# Altium schematic sheet Power.SchDoc(Power)
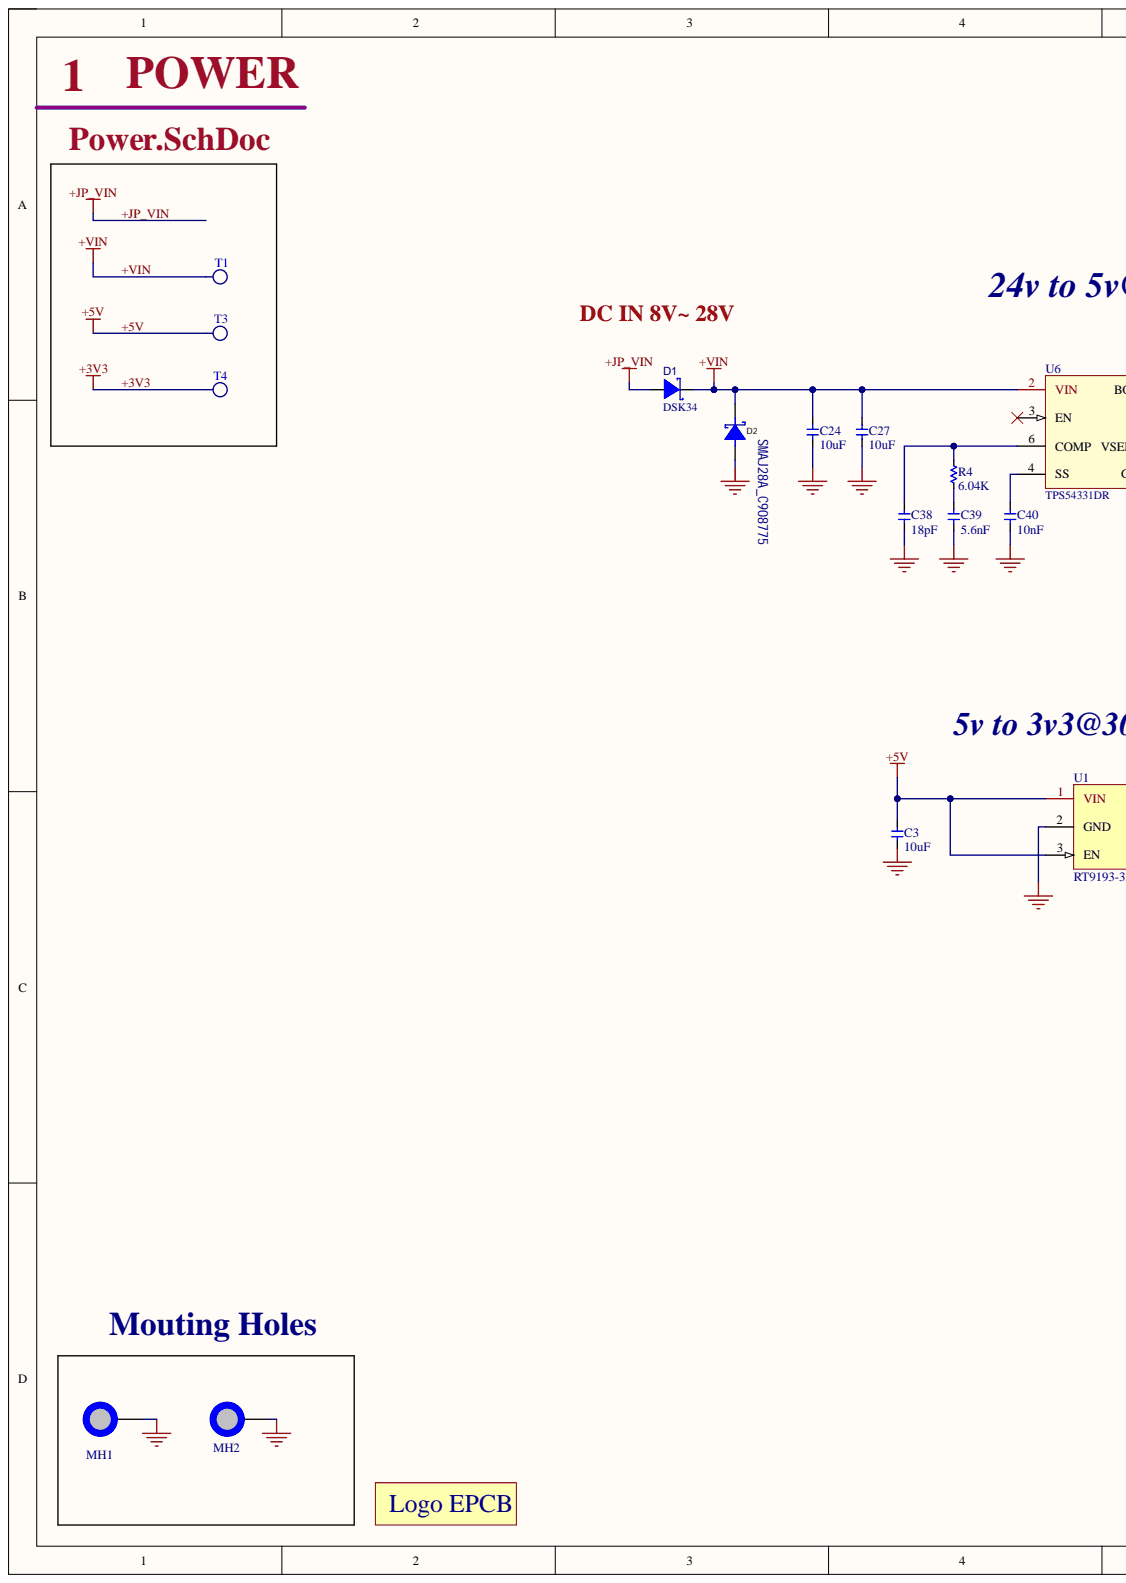
[diagram p0: Power.SchDoc, left]
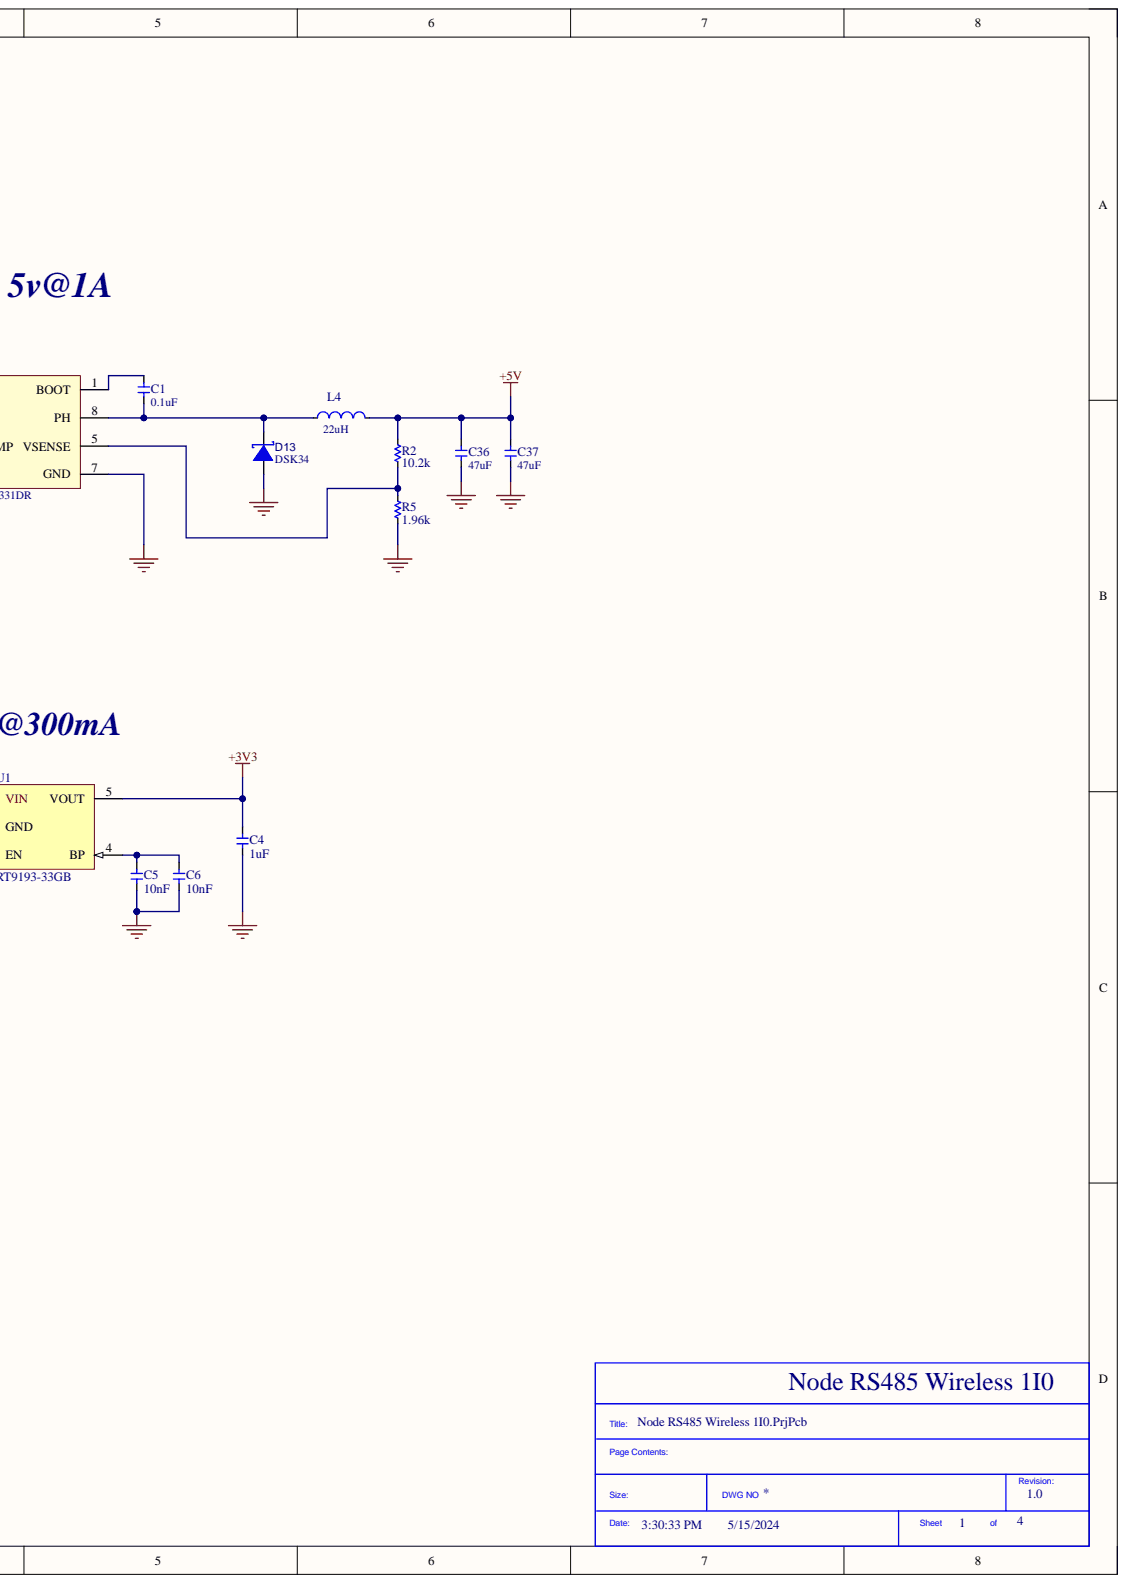
[diagram p1: Power.SchDoc, right]

## Parts
C1  0.1uF  [C_0603]
C3  10uF  [C_0805]
C4  1uF  [C_0603]
C5  10nF  [C_0402]
C6  10nF  [C_0402]
C24  10uF  [C_0805]
C27  10uF  [C_0805]
C36  47uF  [C_0805]
C37  47uF  [C_0805]
C38  18pF  [C_0402]
C39  5.6nF  [C_0402]
C40  10nF  [C_0402]
D1  DSK34  [SOD-123_L2.8-W1.8-LS3.7-RD]
D2  SMAJ28A_C908775  [DO-214AC_L4.3-W2.7-LS5.0-RD]
D13  DSK34  [SOD-123_L2.8-W1.8-LS3.7-RD]
L4  22uH  [IND-SMD_L7.1-W6.6]
LO2  LOGO EPCB  [LOGO EPCB TopLayer]  (value not drawn)  (no BOM)
MH1  MH_6.0MMC_3.5MM  [MH_6.0MMD_3.5MM - Via]  (value not drawn)  (no BOM)
MH2  MH_6.0MMC_3.5MM  [MH_6.0MMD_3.5MM - Via]  (value not drawn)  (no BOM)
R2  10.2k  [R_0402]
R4  6.04K  [R_0402]
R5  1.96k  [R_0402]
T1  TEST-POINT'4545'  [TP-D45MIL]  (value not drawn)  (no BOM)
T3  TEST-POINT'4545'  [TP-D45MIL]  (value not drawn)  (no BOM)
T4  TEST-POINT'4545'  [TP-D45MIL]  (value not drawn)  (no BOM)
U1  RT9193-33GB  [SOT-23-5_L3.0-W1.7-P0.95-LS2.8-BR]
U6  TPS54331DR  [SOIC-8_3.9x4.9x1.27P]

## Nets
GND: C3.1 C4.1 C5.1 C6.1 C24.1 C27.1 C36.1 C37.1 C38.1 C39.1 C40.1 D2.2 D13.2 MH1.1 MH2.1 R5.2 U1.2(GND) U6.7(GND)
+3V3: C4.2 T4.T U1.5(VOUT)
+5V: C3.2 C36.2 C37.2 L4.2 R2.1 T3.T U1.1(VIN) U1.3(EN)
+JP_VIN: D1.2
+VIN: C24.2 C27.2 D1.1 D2.1 T1.T U6.2(VIN)
NetC1_1: C1.1 D13.1 L4.1 U6.8(PH)
NetC1_2: C1.2 U6.1(BOOT)
NetC5_2: C5.2 C6.2 U1.4(BP)
NetC38_2: C38.2 R4.1 U6.6(COMP)
NetC39_2: C39.2 R4.2
NetC40_2: C40.2 U6.4(SS)
NetR2_2: R2.2 R5.1 U6.5(VSENSE)

Not connected: U6 3(EN)

## Library data of the parts
C1 = CC0603KRX7R9BB104: 100NF 50V 0603 ROHS; Manufacturer: YAGEO; Assembly type: SMT Assembly; Component Kind: Standard; ComponentLink1Description: Digikey Link; ComponentLink1URL: https://www.digikey.com/en/products/detail/yageo/CC0603KRX7R9BB104/2103082?s=N4IgTCBcDaIMwEYEFoFwCzuWZA5AIiALoC%2BQA; ComponentLink2Description: LCSC Link; ComponentLink2URL: https://jlcpcb.com/parts/componentSearch?searchTxt=10k%200603; LCSC Part Number: C14663; Library Name: Node RS485 Wireless 1I0.SCHLIB; Manufacturer Part Number: CC0603KRX7R9BB104; Package: 0603
C3 = CL21A106KAYNNNE: 10UF 25V 0805 ROHS; Manufacturer: Samsung Electro-Mechanics; Assembly Type: SMT Assembly; Component Kind: Standard; ComponentLink1Description: LCSC Link; ComponentLink1URL: https://lcsc.com/product-detail/Multilayer-Ceramic-Capacitors-MLCC-SMD-SMT_SAMSUNG_CL21A106KAYNNNE_10uF-106-10-25V_C15850.html/?href=jlc-SMT; LCSC Part Number: C15850; Library Name: USB to Multi RS485 1.0.SCHLIB; Manufacturer Part Number: CL21A106KAYNNNE; Package: 0805
C4 = CL10A105KB8NNNC: 1UF 50V 0603 ROHS; Manufacturer: Samsung Electro-Mechanics; Assembly Type: SMT Assembly; Component Kind: Standard; ComponentLink1Description: Digikey Link; ComponentLink1URL: https://www.digikey.com/en/products/detail/samsung-electro-mechanics/CL10A105KB8NNNC/3887518?s=N4IgTCBcDaIMIBkCMAGAgqgrAaQEIA4A5YuEAXQF8g; ComponentLink2Description: LCSC Link; ComponentLink2URL: https://jlcpcb.com/parts/componentSearch?searchTxt=10k%200603; LCSC Part Number: C15849; Library Name: Dev Board STM OLED 2.0.SCHLIB; Manufacturer Part Number: CL10A105KB8NNNC; Package: 0603
C5 C6 C40 = 0402B103K500NT: 10nF 50V X7R ±10% 0402 Multilayer Ceramic Capacitors MLCC - SMD/SMT ROH; Manufacturer: FH(Guangdong Fenghua Advanced Tech); Manufacturer Part Number: 0402CG120J500NT; Assembly Type: SMT Assembly; Component Kind: Standard; ComponentLink1Description: Digikey Link; ComponentLink2Description: LCSC Link; ComponentLink2URL: https://jlcpcb.com/parts/componentSearch?searchTxt=10k%200603; LCSC Part Number: C1547; Package: 0402
  C5 C6: Library Name: Dev Board STM OLED 2.0.SchLib
  C40: Library Name: Node RS485 Wireless 1I0.SCHLIB
C24 C27 = GRM21BR61H106KE43L: 10uF ±10% 50V X5R 0805; Manufacturer: Murata Electronics; Assembly Type: SMT Assembly; Component Kind: Standard; ComponentLink1Description: LCSC Link; ComponentLink1URL: https://lcsc.com/product-detail/Multilayer-Ceramic-Capacitors-MLCC-SMD-SMT_SAMSUNG_CL21A106KAYNNNE_10uF-106-10-25V_C15850.html/?href=jlc-SMT; LCSC Part Number: C440198; Library Name: Node RS485 Wireless 1I0.SCHLIB; Manufacturer Part Number: GRM21BR61H106KE43L; Package: 0805
C36 C37 = GRM21BR61A476ME15L: 47uF ±20% 10V X5R 0805 Multilayer Ceramic Capacitors MLCC - SMD/SMT RoHS; Manufacturer: Murata Electronics; Assembly Type: SMT Assembly; Component Kind: Standard; ComponentLink1Description: LCSC Link; ComponentLink1URL: https://lcsc.com/product-detail/Multilayer-Ceramic-Capacitors-MLCC-SMD-SMT_SAMSUNG_CL21A106KAYNNNE_10uF-106-10-25V_C15850.html/?href=jlc-SMT; LCSC Part Number: C124129; Library Name: Node RS485 Wireless 1I0.SCHLIB; Manufacturer Part Number: GRM21BR61A476ME15L; Package: 0805
C38 = 0402CG180J500NT: 50V 18pF C0G ±5% 0402 Multilayer Ceramic Capacitors MLCC - SMD/SMT ROHS; Manufacturer: FH (Guangdong Fenghua Advanced Tech); Assembly Type: SMT Assembly; Component Kind: Standard; ComponentLink1Description: Digikey Link; ComponentLink1URL: https://www.digikey.com/en/products/detail/yageo/CC0603KRX7R9BB104/2103082?s=N4IgTCBcDaIMwEYEFoFwCzuWZA5AIiALoC%2BQA; ComponentLink2Description: LCSC Link; ComponentLink2URL: https://jlcpcb.com/parts/componentSearch?searchTxt=10k%200603; LCSC Part Number: C1549; Library Name: Node RS485 Wireless 1I0.SCHLIB; Manufacturer Part Number: 0402CG180J500NT; Package: 0402
C39 = 0402B562K500NT: 5.6nF 50V X7R ±10% 0402  Multilayer Ceramic Capacitors MLCC - SMD/SMT ROHS; Manufacturer: FH(Guangdong Fenghua Advanced Tech); Assembly Type: SMT Assembly; Component Kind: Standard; ComponentLink1Description: Digikey Link; ComponentLink2Description: LCSC Link; ComponentLink2URL: https://jlcpcb.com/parts/componentSearch?searchTxt=10k%200603; LCSC Part Number: C1540; Library Name: Node RS485 Wireless 1I0.SCHLIB; Manufacturer Part Number: 0402B562K500NT; Package: 0402
D1 D13 = DSK34: DSK34 40V 550mV@3A 3A SOD-123 Schottky Barrier Diodes (SBD) ROHS Copy; Manufacturer: MDD（Microdiode Electronics); Assembly Type: SMT Assembly; Component Kind: Standard; LCSC Part Number: C41029; Library Name: Node RS485 Wireless 1I0.SCHLIB; Manufacturer Part Number: DSK34; Package: SOD-123
D2 = SMAJ28A_C908775: SMAJ28A 8.8A 45.4V 31.1V 28V SMA(DO-214AC)  Electrostatic and Surge Protection (TVS/ESD) ROHS; Manufacturer: GOODWORK(固得沃克); Add into BOM: yes; Reverse Leakage Current (Ir): 5uA; Type: TVS; Assembly Type: SMT Assembly; Breakdown Voltage: 31.1V; Component Kind: Standard; Convert to PCB: yes; Datasheet: https://atta.szlcsc.com/upload/public/pdf/source/20201231/C908757_5436EAF671B686EFC2F0DD2098F0ED89.pdf; Device: SMAJ28A_C908775; LCSC Part Name: 单向TVS 28V截止 8.8A峰值脉冲; LCSC Part Number: C908775; Library Name: Node RS485 Wireless 1I0.SCHLIB; Manufacturer Part Number: SMAJ28A; Maximum Clamping Voltage: 45.4V; Package: SMA(DO-214AC); Peak Pulse Current (Ipp)@10/1000us: 8.8A; Reverse Stand-Off Voltage (Vrwm): 28V; Supplier: LCSC; Symbol: SMAJ28A_C908775
L4 = IND_SLO0630H220MTT: 22uH ±20% SMD Power Inductors ROHS; Manufacturer: Sunltech Tech; Assembly Type: SMT Assembly; Component Kind: Standard; LCSC Part Number: C207843; Library Name: Node RS485 Wireless 1I0.SCHLIB; Manufacturer Part Number: SLO0630H220MTT; Package: SMD_7.1x6.6x3mm
MH1 MH2 = MH_6.0MMC_3.5MM_Via: Mounting Hole 6.0MMC 3.5MM; .Creator: MM; Library Name: Node RS485 Wireless 1I0.SCHLIB
R2 = AC0402FR-0710K2L: 10.2k ±1% 10.2kΩ 0402  Chip Resistor - Surface Mount ROHS; Manufacturer: YAGEO; Assembly Type: SMT Assembly; Component Kind: Standard; LCSC Part Number: C226746; Library Name: Node RS485 Wireless 1I0.SCHLIB; Manufacturer Part Number: AC0402FR-0710K2L; Package: 0402
R4 = RC0402FR-076K04L: 6.04K ±1% 6.04kΩ 0402  Chip Resistor - Surface Mount ROHS; Manufacturer: YAGEO; Assembly Type: SMT Assembly; Component Kind: Standard; LCSC Part Number: C97819; Library Name: Node RS485 Wireless 1I0.SCHLIB; Manufacturer Part Number: RC0402FR-076K04L; Package: 0402
R5 = RC-02K1961FT: 1.96k ±1% 1.96kΩ 0402  Chip Resistor - Surface Mount ROHS; Manufacturer: FH (Guangdong Fenghua Advanced Tech); Assembly Type: SMT Assembly; Component Kind: Standard; LCSC Part Number: C324853; Library Name: Node RS485 Wireless 1I0.SCHLIB; Manufacturer Part Number: RC-02K1961FT; Package: 0402
T1 T3 T4 = TEST-POINT'4545': Manufacturer: No; Description: TEST-POINT'4545'; LCSC Part Number: No; Library Name: Node RS485 Wireless 1I0.SCHLIB; Manufacturer Part Number: No; Package: No
U1 = RT9193-33GB: 5.5V 300mV @ 300mA - - Fixed 3.3V SOT-23-5 Linear Voltage Regulators (LDO) ROHS; Manufacturer: Richtek Tech; Assembly Type: SMT Assembly; Component Kind: Standard; LCSC Part Number: C15651; Library Name: Dev Board STM OLED 2.0.SchLib; Manufacturer Part Number: RT9193-33GB; Package: SOT-23-5
U6 = TPS54331DR: TPS54331DR 3A 28V SOIC-8_150mil DC-DC Converters ROHS; Manufacturer: Texas Instruments; Assembly Type: SMT Assembly; Component Kind: Standard; LCSC Part Number: C9865; Library Name: Node RS485 Wireless 1I0.SCHLIB; Manufacturer Part Number: TPS54331DR; Package: SOIC-8_3.9x4.9x1.27P
LO2 = LOGO EPCB: Description: LOGO EPCB; Library Name: Dev Board STM OLED 2.0.SchLib
